# Altium schematic sheet NRF24L01.SchDoc(NRF24L01)
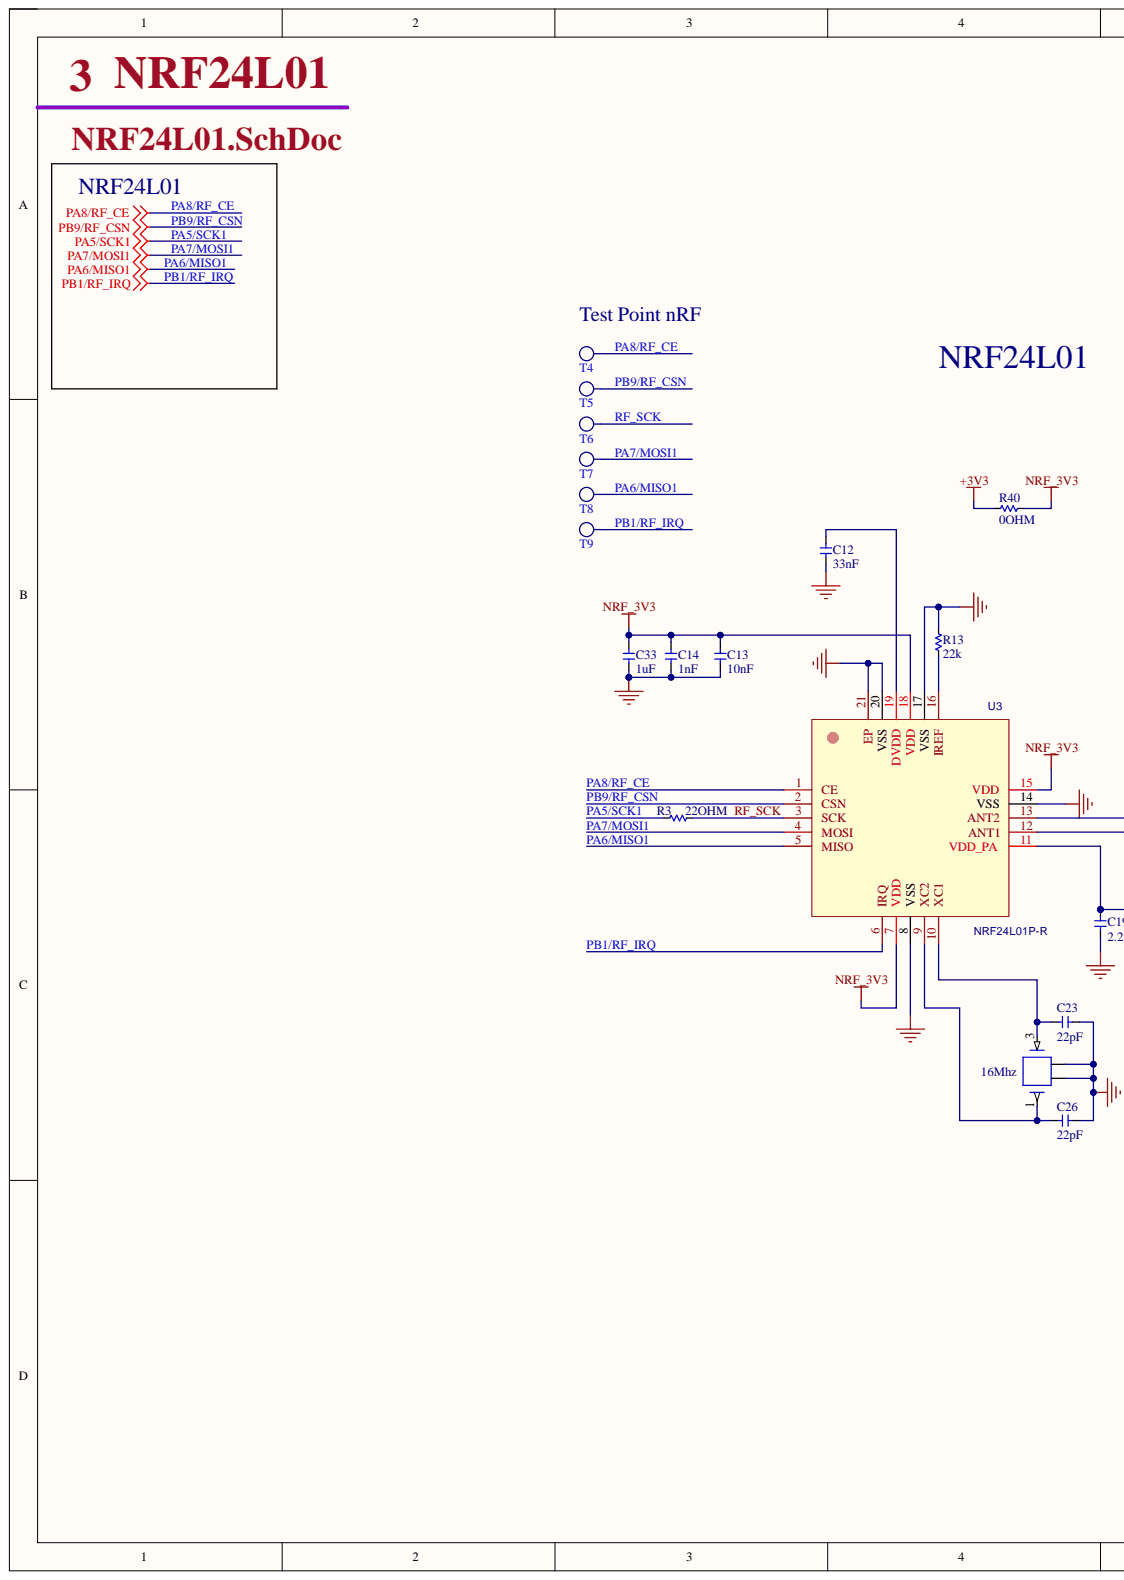
[diagram p0: NRF24L01.SchDoc, left]
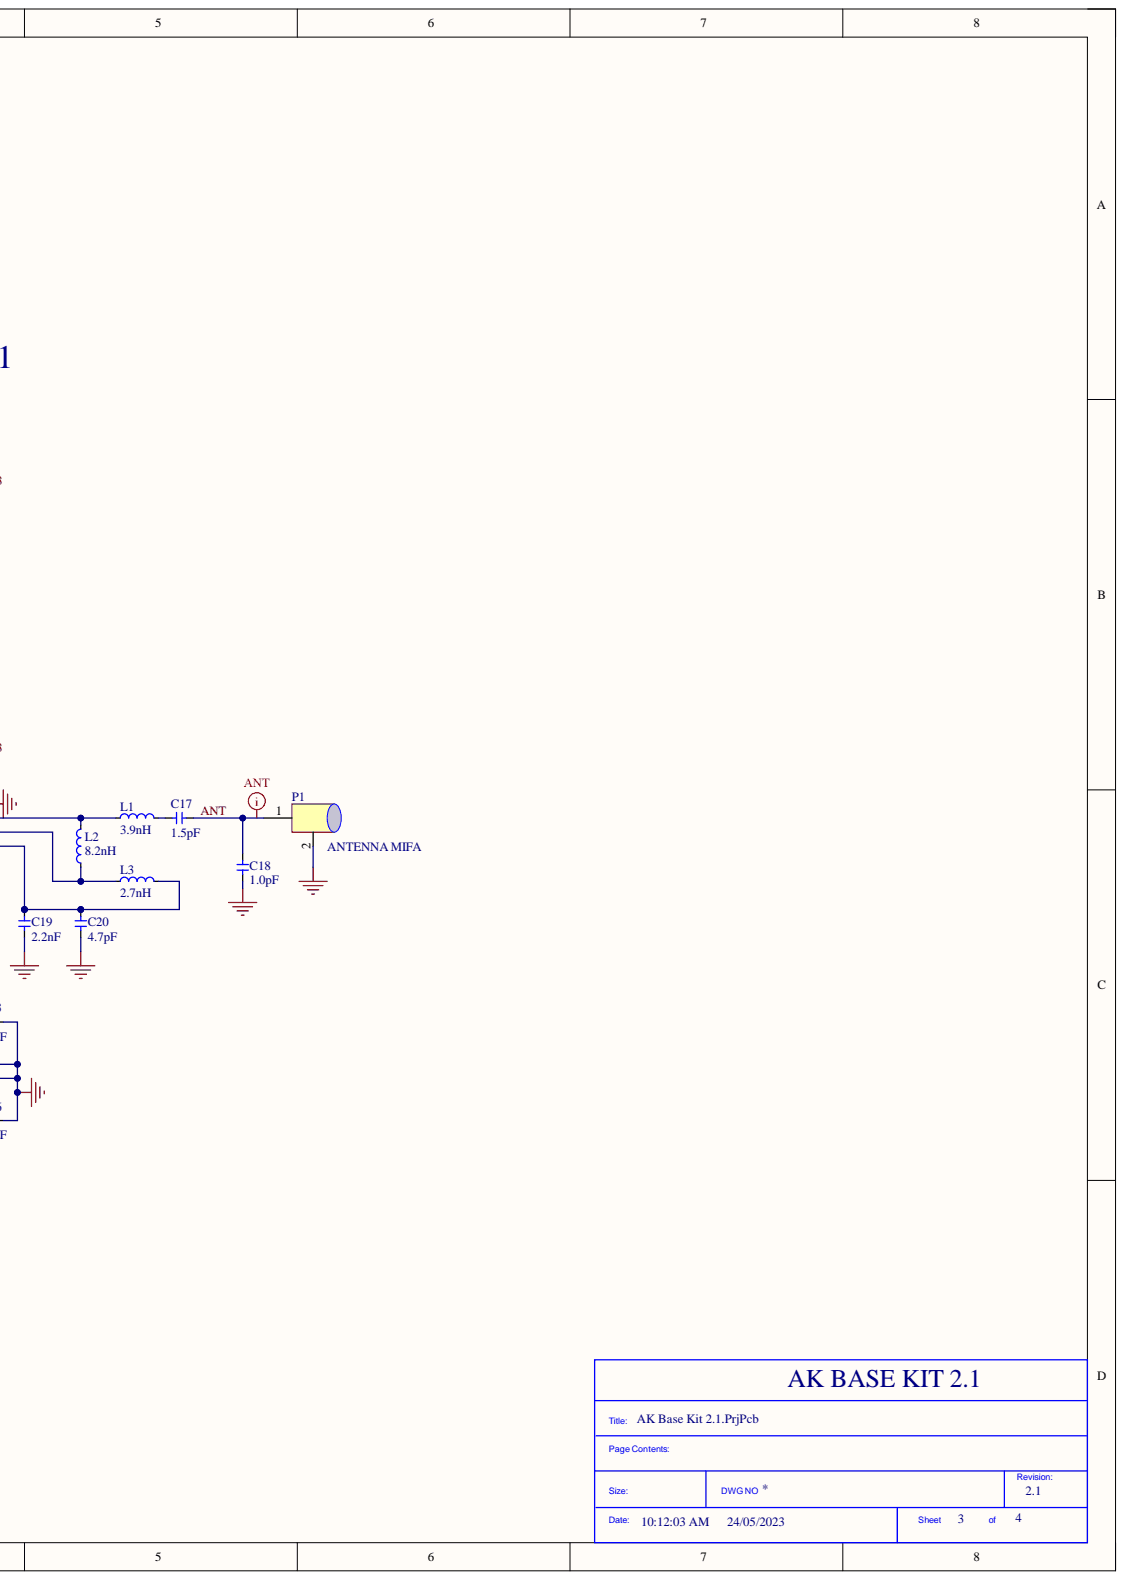
[diagram p1: NRF24L01.SchDoc, right]

## Parts
C12  33nF  [C_0402]
C13  10nF  [C_0402]
C14  1nF  [C_0402]
C17  1.5pF  [C_0402]
C18  1.0pF  [C_0402]
C19  2.2nF  [C_0402]
C20  4.7pF  [C_0402]
C23  22pF  [C_0402]
C26  22pF  [C_0402]
C33  1uF  [C_0603]
L1  3.9nH  [L_0402]
L2  8.2nH  [L_0402]
L3  2.7nH  [L_0402]
P1  ANTENNA MIFA  [ANTENNA MIFA]  (no BOM)
R3  22OHM  [R_0402]
R13  22k  [R_0402]
R40  0OHM  [R_0603]
T4  TEST-POINT'4545'  [TP-D45MIL]  (value not drawn)  (no BOM)
T5  TEST-POINT'4545'  [TP-D45MIL]  (value not drawn)  (no BOM)
T6  TEST-POINT'4545'  [TP-D45MIL]  (value not drawn)  (no BOM)
T7  TEST-POINT'4545'  [TP-D45MIL]  (value not drawn)  (no BOM)
T8  TEST-POINT'4545'  [TP-D45MIL]  (value not drawn)  (no BOM)
T9  TEST-POINT'4545'  [TP-D45MIL]  (value not drawn)  (no BOM)
U3  NRF24L01P-R  [QFN-20_L4.0-W4.0-P0.50-BL-EP]
X3  16Mhz  [SMD-3225_4P]

## Nets
+3V3: R40.2
GND: C12.1 C13.1 C14.2 C18.1 C19.1 C20.1 C23.1 C26.1 C33.1 P1.2 R13.1 U3.8(VSS) U3.14(VSS) U3.17(VSS) U3.20(VSS) U3.21(EP) X3.2 X3.4
NRF_3V3: C13.2 C14.1 C33.2 R40.1 U3.7(VDD) U3.15(VDD) U3.18(VDD)
ANT: C17.2 C18.2 P1.1
PA5/SCK1: R3.2
PA6/MISO1: T8.T U3.5(MISO)
PA7/MOSI1: T7.T U3.4(MOSI)
PA8/RF_CE: T4.T U3.1(CE)
PB1/RF_IRQ: T9.T U3.6(IRQ)
PB9/RF_CSN: T5.T U3.2(CSN)
RF_SCK: R3.1 T6.T U3.3(SCK)
NetC12_2: C12.2 U3.19(DVDD)
NetC17_1: C17.1 L1.2
NetC19_2: C19.2 C20.2 L3.2 U3.11(VDD_PA)
NetC23_2: C23.2 U3.10(XC1) X3.3
NetC26_2: C26.2 U3.9(XC2) X3.1
NetL1_1: L1.1 L2.2 U3.13(ANT2)
NetL2_1: L2.1 L3.1 U3.12(ANT1)
NetR13_2: R13.2 U3.16(IREF)

## Library data of the parts
C12 = CC0402KRX7R9BB333: 50V 33nF X7R ±10% 0402 Multilayer Ceramic Capacitors MLCC - SMD/SMT ROHS; Manufacturer: YAGEO; Assembly Type: SMT Assembly; Component Kind: Standard; ComponentLink1Description: Digikey Link; ComponentLink2Description: LCSC Link; ComponentLink2URL: https://jlcpcb.com/parts/componentSearch?searchTxt=10k%200603; LCSC Part Number: C106862; Library Name: Dev Board STM OLED 2.0.SCHLIB; Manufacturer Part Number: CC0402KRX7R9BB333; Package: 0402
C13 = 0402B103K500NT: 10nF 50V X7R ±10% 0402 Multilayer Ceramic Capacitors MLCC - SMD/SMT ROH; Manufacturer: FH(Guangdong Fenghua Advanced Tech); Manufacturer Part Number: 0402CG120J500NT; Assembly Type: SMT Assembly; Component Kind: Standard; ComponentLink1Description: Digikey Link; ComponentLink2Description: LCSC Link; ComponentLink2URL: https://jlcpcb.com/parts/componentSearch?searchTxt=10k%200603; LCSC Part Number: C1547; Library Name: Dev Board STM OLED 2.0.SchLib; Package: 0402
C14 = 0402B102K500NT: 1nF 50V X7R ±10% 0402 Multilayer Ceramic Capacitors MLCC - SMD/SMT ROHS; Manufacturer: FH(Guangdong Fenghua Advanced Tech); Assembly Type: SMT Assembly; Component Kind: Standard; ComponentLink1Description: Digikey Link; ComponentLink2Description: LCSC Link; ComponentLink2URL: https://jlcpcb.com/parts/componentSearch?searchTxt=10k%200603; LCSC Part Number: C1523; Library Name: Dev Board STM OLED 2.0.SchLib; Manufacturer Part Number: 0402B102K500NT; Package: 0402
C17 = 0402CG1R5C500NT: 1.5pF 50V C0G ±0.25pF 0402 Multilayer Ceramic Capacitors MLCC -; Manufacturer: FH(Guangdong Fenghua Advanced Tech); Assembly Type: SMT Assembly; Component Kind: Standard; ComponentLink1Description: Digikey Link; ComponentLink2Description: LCSC Link; ComponentLink2URL: https://jlcpcb.com/parts/componentSearch?searchTxt=10k%200603; LCSC Part Number: C1552; Library Name: Dev Board STM OLED 2.0.SCHLIB; Manufacturer Part Number: 0402CG1R5C500NT; Package: 0402
C18 = 0402CG1R0C500NT: 1.0pF 50V C0G ±5% 0402 Multilayer Ceramic Capacitors MLCC - SMD/SMT ROHS; Manufacturer: FH(Guangdong Fenghua Advanced Tech); Assembly Type: SMT Assembly; Component Kind: Standard; ComponentLink1Description: Digikey Link; ComponentLink2Description: LCSC Link; ComponentLink2URL: https://jlcpcb.com/parts/componentSearch?searchTxt=10k%200603; LCSC Part Number: C1550; Library Name: Dev Board STM OLED 2.0.SchLib; Manufacturer Part Number: 0402CG1R0C500NT; Package: 0402
C19 = 0402B222K500NT: 2.2nF 50V C0G ±5% 0402 Multilayer Ceramic Capacitors MLCC - SMD/SMT ROHS; Manufacturer: FH(Guangdong Fenghua Advanced Tech); Assembly Type: SMT Assembly; Component Kind: Standard; ComponentLink1Description: Digikey Link; ComponentLink2Description: LCSC Link; ComponentLink2URL: https://jlcpcb.com/parts/componentSearch?searchTxt=10k%200603; LCSC Part Number: C1531; Library Name: Dev Board STM OLED 2.0.SchLib; Manufacturer Part Number: 0402B222K500NT; Package: 0402
C20 = 0402CG4R7C500NT: 4.7pF 50V C0G ±5% 0402 Multilayer Ceramic Capacitors MLCC - SMD/SMT ROHS; Manufacturer: FH(Guangdong Fenghua Advanced Tech); Assembly Type: SMT Assembly; Component Kind: Standard; ComponentLink1Description: Digikey Link; ComponentLink2Description: LCSC Link; ComponentLink2URL: https://jlcpcb.com/parts/componentSearch?searchTxt=10k%200603; LCSC Part Number: C1569; Library Name: Dev Board STM OLED 2.0.SCHLIB; Manufacturer Part Number: 0402CG4R7C500NT; Package: 0402
C23 C26 = 0402CG220J500NT: 22pF 50V C0G ±5% 0402 Multilayer Ceramic Capacitors MLCC - SMD/SMT ROHS; Manufacturer: FH(Guangdong Fenghua Advanced Tech); Assembly Type: SMT Assembly; Component Kind: Standard; ComponentLink1Description: Digikey Link; ComponentLink2Description: LCSC Link; ComponentLink2URL: https://jlcpcb.com/parts/componentSearch?searchTxt=10k%200603; LCSC Part Number: C1555; Library Name: Dev Board STM OLED 2.0.SCHLIB; Manufacturer Part Number: 0402CG220J500NT; Package: 0402
C33 = CL10A105KB8NNNC: 1UF 50V 0603 ROHS; Manufacturer: Samsung Electro-Mechanics; Assembly Type: SMT Assembly; Component Kind: Standard; ComponentLink1Description: Digikey Link; ComponentLink1URL: https://www.digikey.com/en/products/detail/samsung-electro-mechanics/CL10A105KB8NNNC/3887518?s=N4IgTCBcDaIMIBkCMAGAgqgrAaQEIA4A5YuEAXQF8g; ComponentLink2Description: LCSC Link; ComponentLink2URL: https://jlcpcb.com/parts/componentSearch?searchTxt=10k%200603; LCSC Part Number: C15849; Library Name: Dev Board STM OLED 2.0.SCHLIB; Manufacturer Part Number: CL10A105KB8NNNC; Package: 0603
L1 = FHW0402UC3N9JST: 3.9nH 840mA ±5% 66mΩ 0402 Inductors (SMD) ROHS; Manufacturer: FH(Guangdong Fenghua Advanced Tech); Assembly Type: SMT Assembly; Component Kind: Standard; LCSC Part Number: C317939; Library Name: Dev Board STM OLED 2.0.SCHLIB; Manufacturer Part Number: FHW0402UC3N9JST; Package: 0402
L2 = FHW0402UC8N2JST: 8.2nH 680mA ±5% 100mΩ 0402 Inductors (SMD) ROHS; Manufacturer: FH(Guangdong Fenghua Advanced Tech); Assembly Type: SMT Assembly; Component Kind: Standard; LCSC Part Number: C317946; Library Name: Dev Board STM OLED 2.0.SCHLIB; Manufacturer Part Number: FHW0402UC8N2JST; Package: 0402
L3 = FHW0402UC2N7KST: 2.7nH 640mA  ±10% 120mΩ 0402 Inductors (SMD) ROHS; Manufacturer: FH(Guangdong Fenghua Advanced Tech); Assembly Type: SMT Assembly; Component Kind: Standard; LCSC Part Number: C317935; Library Name: Dev Board STM OLED 2.0.SchLib; Manufacturer Part Number: FHW0402UC2N7KST; Package: 0402
P1 = ANTENNA MIFA: ANTENNA IFA PCB; Library Name: Dev Board STM OLED 2.0.SCHLIB
R3 = 0402WGF220JTCE: 22Ω 0402 62.5mW Thick Film Resistors 50V ±100ppm/℃ ±1% -55℃~+155℃; Manufacturer: Uniroyal Elec; Assembly Type: SMT Assembly; Component Kind: Standard; LCSC Part Number: C25092; Library Name: Dev Board STM OLED 2.0.SCHLIB; Manufacturer Part Number: 0402WGF220JTCE; Package: 0402
R13 = 0402WGF2202TCE: 22k 0402 62.5mW Thick Film Resistors 50V ±100ppm/℃ ±1% -55℃~+155℃ 22kΩ; Manufacturer: Uniroyal Elec; Assembly Type: SMT Assembly; Component Kind: Standard; LCSC Part Number: C25768; Library Name: Dev Board STM OLED 2.0.SchLib; Manufacturer Part Number: 0402WGF2202TCE; Package: 0402
R40 = 0603WAF0000T5E: 0OHMS ±1% 1/10W 0603 ROHS; Manufacturer: Uniroyal Elec; Assembly Type: SMT Assembly; Component Kind: Standard; LCSC Part Number: C21189; Library Name: Dev Board STM OLED 2.0.SCHLIB; Manufacturer Part Number: 0603WAF0000T5E; Package: 0603
T4-T9 = TEST-POINT'4545': Manufacturer: No; Description: TEST-POINT'4545'; LCSC Part Number: No; Library Name: Dev Board STM OLED 2.0.SchLib; Manufacturer Part Number: No; Package: No
U3 = NRF24L01P-R: NRF24L01P-R SPI QFN-20_4x4x05P RF Transceiver ICs ROHS; Manufacturer: NORDIC; Assembly Type: SMT Assembly; Component Kind: Standard; LCSC Part Number: C8791; Library Name: Dev Board STM OLED 2.0.SchLib; Manufacturer Part Number: NRF24L01P-R; Package: QFN-20_4x4x05P
X3 = X322516MLB4SI: 16MHz SMD Crystal Resonator 9pF ±10ppm ±30ppm -40℃~+85℃ SMD3225-4P Crystals ROHS; Manufacturer: Yangxing Tech; Assembly Type: SMT Assembly; Component Kind: Standard; LCSC Part Number: C13738; Library Name: Dev Board STM OLED 2.0.SchLib; Manufacturer Part Number: X322516MLB4SI; Package: SMD-3225_4P
